# Altium schematic sheet Interfaces.SchDoc(Interfaces)
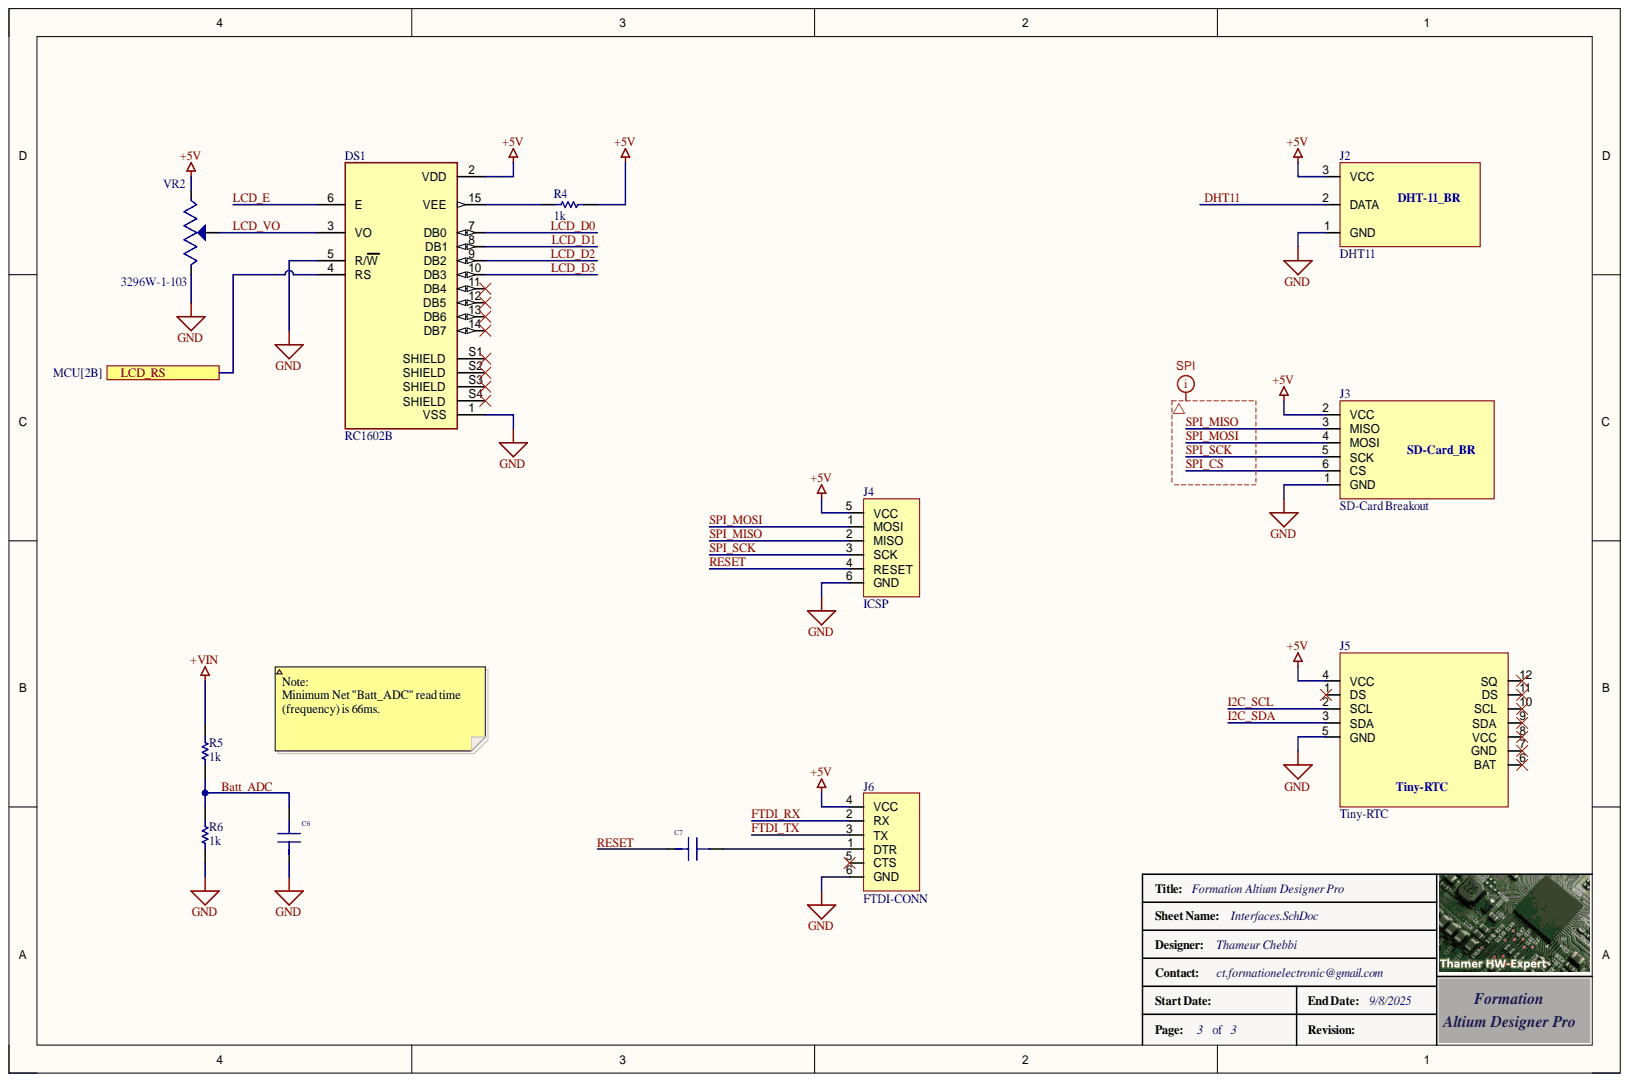
[diagram p0: Interfaces.SchDoc]

## Parts
C6  =Value  [C 0805(2012)x0.85]
C7  =Value  [C 0805(2012)x0.85]
DS1  RC1602B  [LCD_RC1602B-BIW-CSV]
J2  DHT11  [DHT11-BREAKOUT]
J3  SD-Card Breakout  [SD-Card Breakout]
J4  ICSP  [FTDI]
J5  Tiny-RTC  [Tiny-RTC]
J6  FTDI-CONN  [FTDI]
R4  1k  [RESC2013X50X30LL20T20]
R5  1k  [FP-CRCW1206-e3-IPC_B]
R6  1k  [FP-CRCW1206-e3-IPC_B]
VR2  3296W-1-103  [TRIM_3296W-1-103]

## Ports
LCD_RS

## Nets
+5V: DS1.2(VDD) J2.3 J3.2(VCC) J4.5(VCC) J5.4(VCC) J6.4(VCC) R4.1 VR2.3
+VIN: R5.2
GND: C6.1 DS1.1(VSS) DS1.5(R/W) J2.1 J3.1(GND) J4.6(GND) J5.5(GND) J6.6(GND) R6.1 VR2.1
Batt_ADC: C6.2 R5.1 R6.2
DHT11: J2.2
FTDI_RX: J6.2(RX)
FTDI_TX: J6.3(TX)
I2C_SCL: J5.2(SCL)
I2C_SDA: J5.3(SDA)
LCD_D0: DS1.7(DB0)
LCD_D1: DS1.8(DB1)
LCD_D2: DS1.9(DB2)
LCD_D3: DS1.10(DB3)
LCD_E: DS1.6(E)
LCD_RS: DS1.4(RS) ; port LCD_RS
LCD_VO: DS1.3(VO) VR2.2
RESET: C7.1 J4.4(RESET)
SPI_CS: J3.6(CS)
SPI_MISO: J3.3(MISO) J4.2(MISO)
SPI_MOSI: J3.4(MOSI) J4.1(MOSI)
SPI_SCK: J3.5(SCK) J4.3(SCK)
NetC7_2: C7.2 J6.1(DTR)
NetDS1_15: DS1.15(VEE) R4.2

Not connected: DS1 11(DB4) 12(DB5) 13(DB6) 14(DB7) S1(SHIELD) S2(SHIELD) S3(SHIELD) S4(SHIELD); J5 1(DS) 6(BAT) 7(GND) 8(VCC) 9(SDA) 10(SCL) 11(DS) 12(SQ); J6 5(CTS)

## Library data of the parts
C6 C7 = C 0805    50V X7R - 0*: SMD 0805     50V X7R 10%; Manufacturer: Samsung; Package-mm: 2012; TYPE: Capacitors; Component Kind: Standard; ComponentLink1Description: Datasheet link; ComponentLink1URL: https://store.comet.bg/download-file.php?id=11; Mount Type: SMT; Package: 0805; Published: 07.04.2019; RoHS: Y; Supplier 1: Comet; Technology: Ceramic  MLCC; TempCo: X7R; Temperature Range: -55°C ~ +125°C; Tolerance %: ±10%; VDC: 50V; Library Name: SCH_THA.SchLib; Publisher: Hefest
DS1 = RC1602B-BIW-CSV: Display: LCD; alphanumeric; STN Negative; 16x2; blue; LED; PIN: 16; MF: {'manufacturer': u'Raystar'}; Availability: Unavailable; Component Kind: Standard; MP: RC1602B-BIW-CSV; Package: None; Price: None; Library Name: SCH_THA.SchLib
J4 = ICSP: ICSP-CONN; Component Kind: Standard; Library Name: SCH_THA.SchLib
J5 = Tiny-RTC Breakout Board: Component Kind: Standard; Library Name: SCH_THA.SchLib
J6 = FTDI: Component Kind: Standard; Library Name: SCH_THA.SchLib
R4 = RES-0805-SMD: Component Kind: Standard; Value: 1k; Library Name: SCH_THA.SchLib
R5 R6 = RES-1206-SMD: Component Kind: Standard; Value: 1k; Library Name: SCH_THA.SchLib
VR2 = 3296W-1-103: MF: {'manufacturer': u'Bourns'}; Availability: Unavailable; Component Kind: Standard; Library Name: 3296W-1-103.SchLib; MP: 3296W-1-103; Package: None; Price: None
J2 = DHT11: Component Kind: Standard; Library Name: SCH_THA.SchLib
J3 = SD-Card Breakout: Component Kind: Standard; Library Name: SCH_THA.SchLib
